# Altium schematic sheet Sheet4.SchDoc
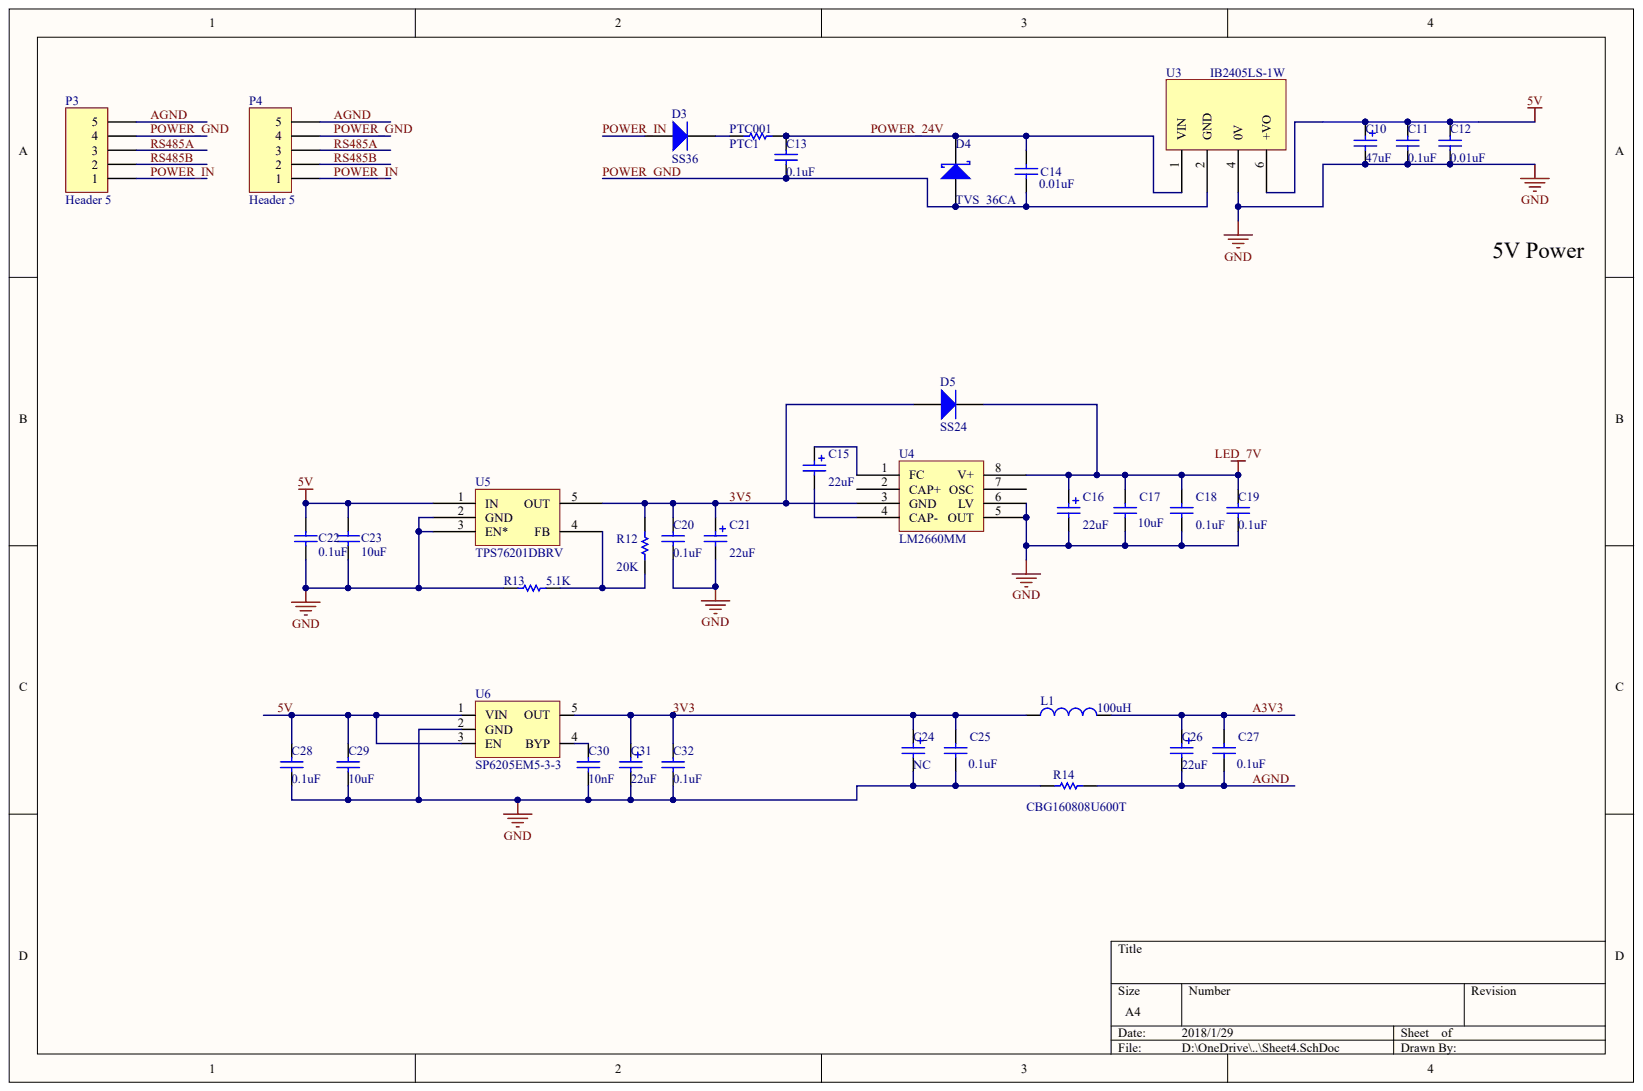
[diagram p0: Sheet4.SchDoc]

## Parts
C10  value 47uF  (hidden comment: Cap Pol2)
C11  value 0.1uF  (hidden comment: Cap)
C12  value 0.01uF  (hidden comment: Cap)
C13  value 0.1uF  (hidden comment: Cap)
C14  value 0.01uF  (hidden comment: Cap)
C15  value 22uF  (hidden comment: Cap Pol2)
C16  value 22uF  (hidden comment: Cap Pol2)
C17  value 10uF  (hidden comment: Cap)
C18  value 0.1uF  (hidden comment: Cap)
C19  value 0.1uF  (hidden comment: Cap)
C20  value 0.1uF  (hidden comment: Cap)
C21  value 22uF  (hidden comment: Cap Pol2)
C22  value 0.1uF  (hidden comment: Cap)
C23  value 10uF  (hidden comment: Cap)
C24  value NC  (hidden comment: Cap Pol2)
C25  value 0.1uF  (hidden comment: Cap)
C26  value 22uF  (hidden comment: Cap Pol2)
C27  value 0.1uF  (hidden comment: Cap)
C28  value 0.1uF  (hidden comment: Cap)
C29  value 10uF  (hidden comment: Cap)
C30  value 10nF  (hidden comment: Cap)
C31  value 22uF  (hidden comment: Cap Pol2)
C32  value 0.1uF  (hidden comment: Cap)
D3  SS36
D4  TVS_36CA
D5  SS24
L1  value 100uH  (hidden comment: Inductor)
P3  Header 5
P4  Header 5
PTC1  value PTC001  (hidden comment: Res1)
R12  value 20K  (hidden comment: Res1)
R13  value 5.1K  (hidden comment: Res1)
R14  value CBG160808U600T  (hidden comment: Magnetic beads)
U3  IB2405LS-1W
U4  LM2660MM
U5  TPS76201DBRV
U6  SP6205EM5-3-3

## Nets
GND: C10.2 C11.1 C12.1 C16.2 C17.1 C18.1 C19.1 C20.1 C21.2 C22.1 C23.1 C24.2 C25.1 C28.1 C29.1 C30.2 C31.2 C32.1 R13.1 R14.2 U3.4(0V) U4.5(OUT) U4.6(LV) U5.2(GND) U5.3(EN*) U6.2(GND)
LED_7V: C16.1 C17.2 C18.2 C19.2 D5.2 U4.8(V+)
3V3: C24.1 C25.2 C31.1 C32.2 L1.1 U6.5(OUT)
3V5: C20.2 C21.1 D5.1 R12.2 U4.3(GND) U5.5(OUT)
5V: C10.1 C11.2 C12.2 C22.2 C23.2 C28.2 C29.2 U3.6(+VO) U5.1(IN) U6.1(VIN) U6.3(EN)
A3V3: C26.1 C27.2 L1.2
AGND: C26.2 C27.1 P3.5 P4.5 R14.1
POWER_24V: C13.2 C14.2 D4.2 PTC1.1 U3.1(VIN)
POWER_GND: C13.1 C14.1 D4.1 P3.4 P4.4 U3.2(GND)
POWER_IN: D3.1 P3.1 P4.1
RS485A: P3.3 P4.3
RS485B: P3.2 P4.2
NetC15_1: C15.1 U4.1(FC)
NetC15_2: C15.2 U4.4(CAP-)
NetC30_1: C30.1 U6.4(BYP)
NetD3_2: D3.2 PTC1.2
NetR12_1: R12.1 R13.2 U5.4(FB)

Not connected: U4 2(CAP+) 7(OSC)

## Library data of the parts
L1 = (no symbol name): Code_IEC: 1005; Published: 8-Jun-2000; LatestRevisionDate: 29-May-2009; LatestRevisionNote: IPC-7351 Footprint Added.; PackageReference: 0402-A; PackageDescription: Chip Inductor; Code_JEITA: 0402; Publisher: Altium Limited
C10-C32 D3-D5 P3 P4 PTC1 R12-R14 U3-U6 = (no symbol name)
  C10 C15 C16 C21 C24 C26 C31: Published: 8-Jun-2000; LatestRevisionDate: 17-Jul-2002; LatestRevisionNote: Re-released for DXP Platform.; PackageReference: POLAR0.8
  C11-C14 C17-C20 C22 C23 C25 C27-C30 C32: Published: 8-Jun-2000; LatestRevisionDate: 17-Jul-2002; LatestRevisionNote: Re-released for DXP Platform.; PackageReference: RAD-0.3
  D3 D5: Published: 8-Jun-2000; LatestRevisionDate: 17-Jul-2002; LatestRevisionNote: Re-released for DXP Platform.; DatasheetVersion: 1997; PackageReference: DO-35; Code_JEDEC: DO-204-AH; ComponentLink1Description: Manufacturer Link; ComponentLink1URL: http://www.fairchildsemi.com/; ComponentLink2Description: Datasheet; ComponentLink2URL: http://www.fairchildsemi.com/ds/1N%2F1N4148.pdf
  D4: Published: 8-Jun-2000; LatestRevisionDate: 17-Jul-2002; LatestRevisionNote: Re-released for DXP Platform.; PackageReference: SMB; Code_JEDEC: DO-214-AA
  P3 P4: LatestRevisionDate: 17-Jul-2002; LatestRevisionNote: Re-released for DXP Platform.
  PTC1 R12-R14: Published: 8-Jun-2000; LatestRevisionDate: 17-Jul-2002; LatestRevisionNote: Re-released for DXP Platform.; PackageReference: AXIAL-0.3
  C10-C32 D3-D5 P3 P4 PTC1 R12-R14: Publisher: Altium Limited
